# Altium schematic sheet Power.SchDoc
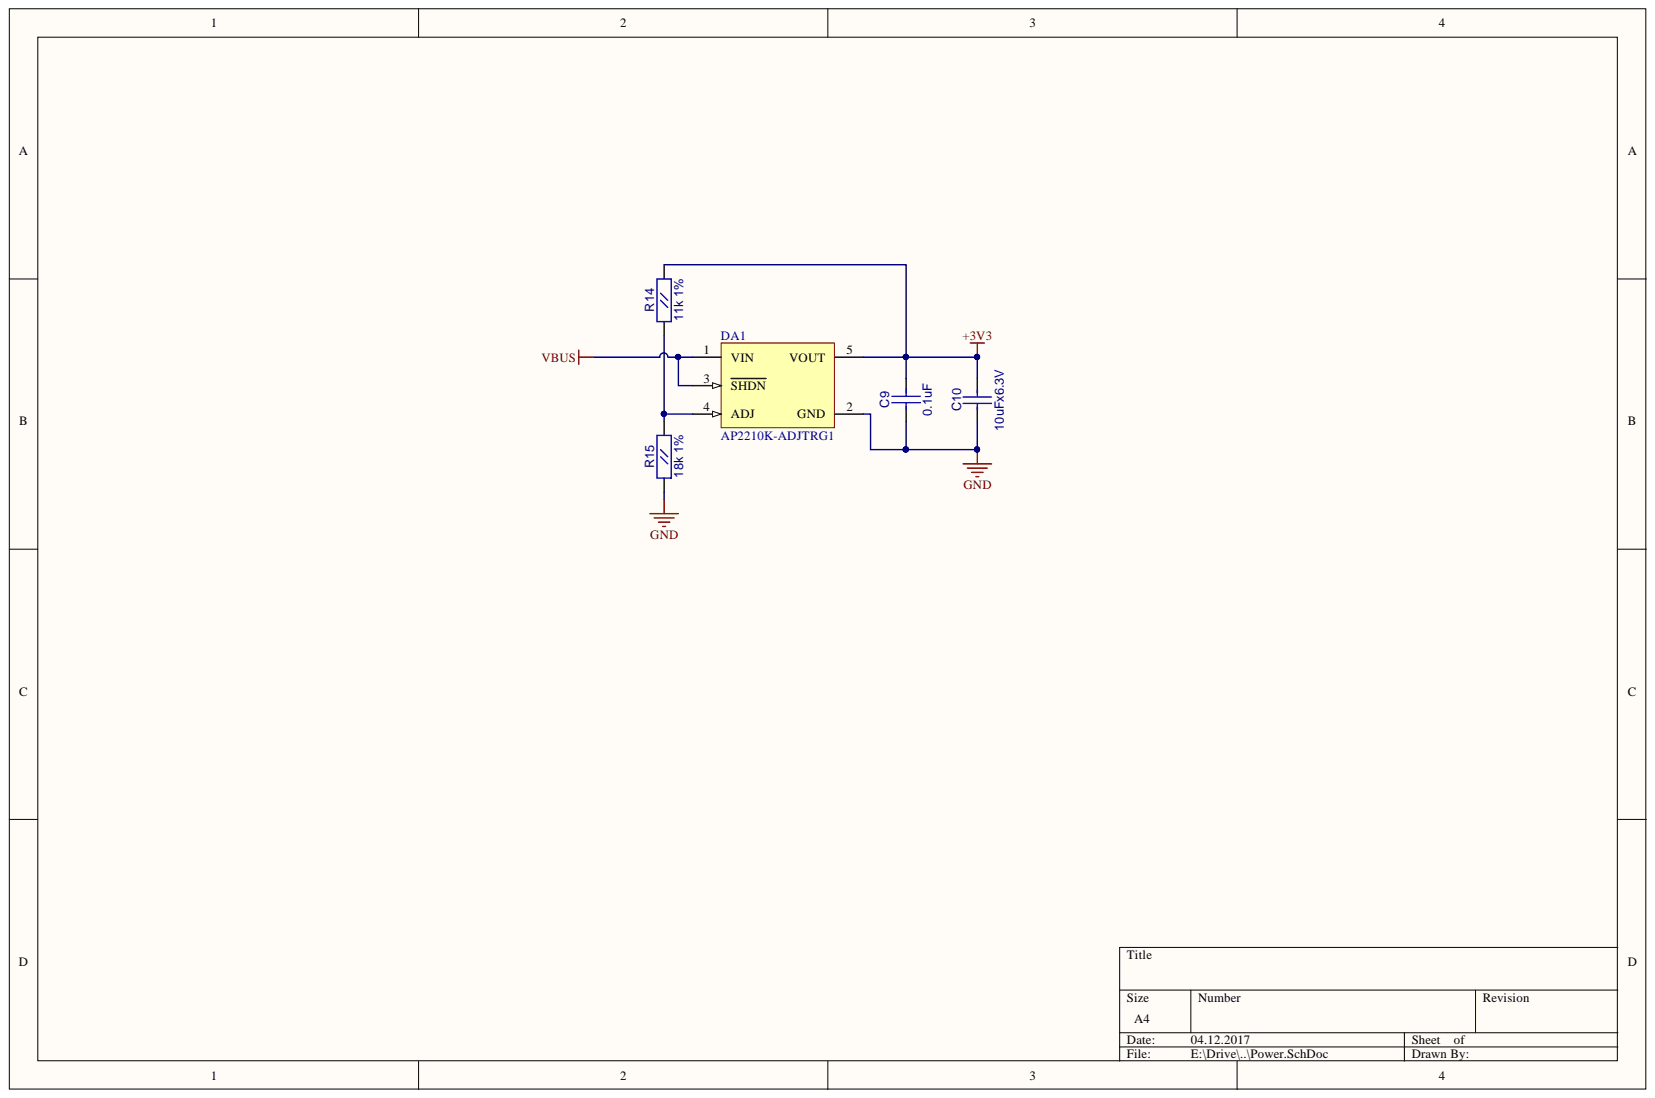
[diagram p0: Power.SchDoc]

## Parts
C9  0.1uF  [CC0603]
C10  10uFx6.3V  [CC0805]
DA1  AP2210K-ADJTRG1  [SOT23-5]
R14  11k 1%  [RC0603]
R15  18k 1%  [RC0603]

## Nets
+3V3: C9.1 C10.2 DA1.5(VOUT) R14.2
GND: C9.2 C10.1 DA1.2(GND) R15.1
VBUS: DA1.1(VIN) DA1.3(SHDN)
NetDA1_4: DA1.4(ADJ) R14.1 R15.2

## Library data of the parts
C9 = CC0603: Component Kind: Standard; Description: CC0603; Value: 0.1uF; Library Name: gprs_modem_2.SchLib
C10 = CC0805: Component Kind: Standard; Description: CC0805; Value: 10uFx6.3V; Library Name: gprs_modem_2.SchLib
DA1 = MCP1824T-ADJE/OT: 300 mA, Low Voltage, Low Quiescent Current LDO Regulator, 5-Pin SOT-223, Extended Temperature, Tape and Reel; Component Kind: Standard; ComponentLink1Description: Manufacturer Link; ComponentLink1URL: http://www.microchip.com; ComponentLink2Description: Datasheet; ComponentLink2URL: http://ww1.microchip.com/downloads/en/DeviceDoc/22070a.pdf; ComponentLink3Description: Packaging Specification; ComponentLink3URL: http://ww1.microchip.com/downloads/en/PackagingSpec/00049AW.pdf; DatasheetVersion: revA, Aug-2007; PackageDescription: 5-Lead Plastic Small Outline Transistor (DC) [SOT-223]; PackageReference: SOT-223-DC5; PackageVersion: revAW, Oct-2008; Published: 29-Jan-2009; Library Name: gprs_modem_2.SchLib; Publisher: Altium Limited
R14 R15 = RC0603: Component Kind: Standard; Description: RC0603; Library Name: gprs_modem_2.SchLib
  R14: Value: 11k 1%
  R15: Value: 18k 1%
